# Altium schematic sheet 03_ATK-DLMP257_Power_USB.SchDoc
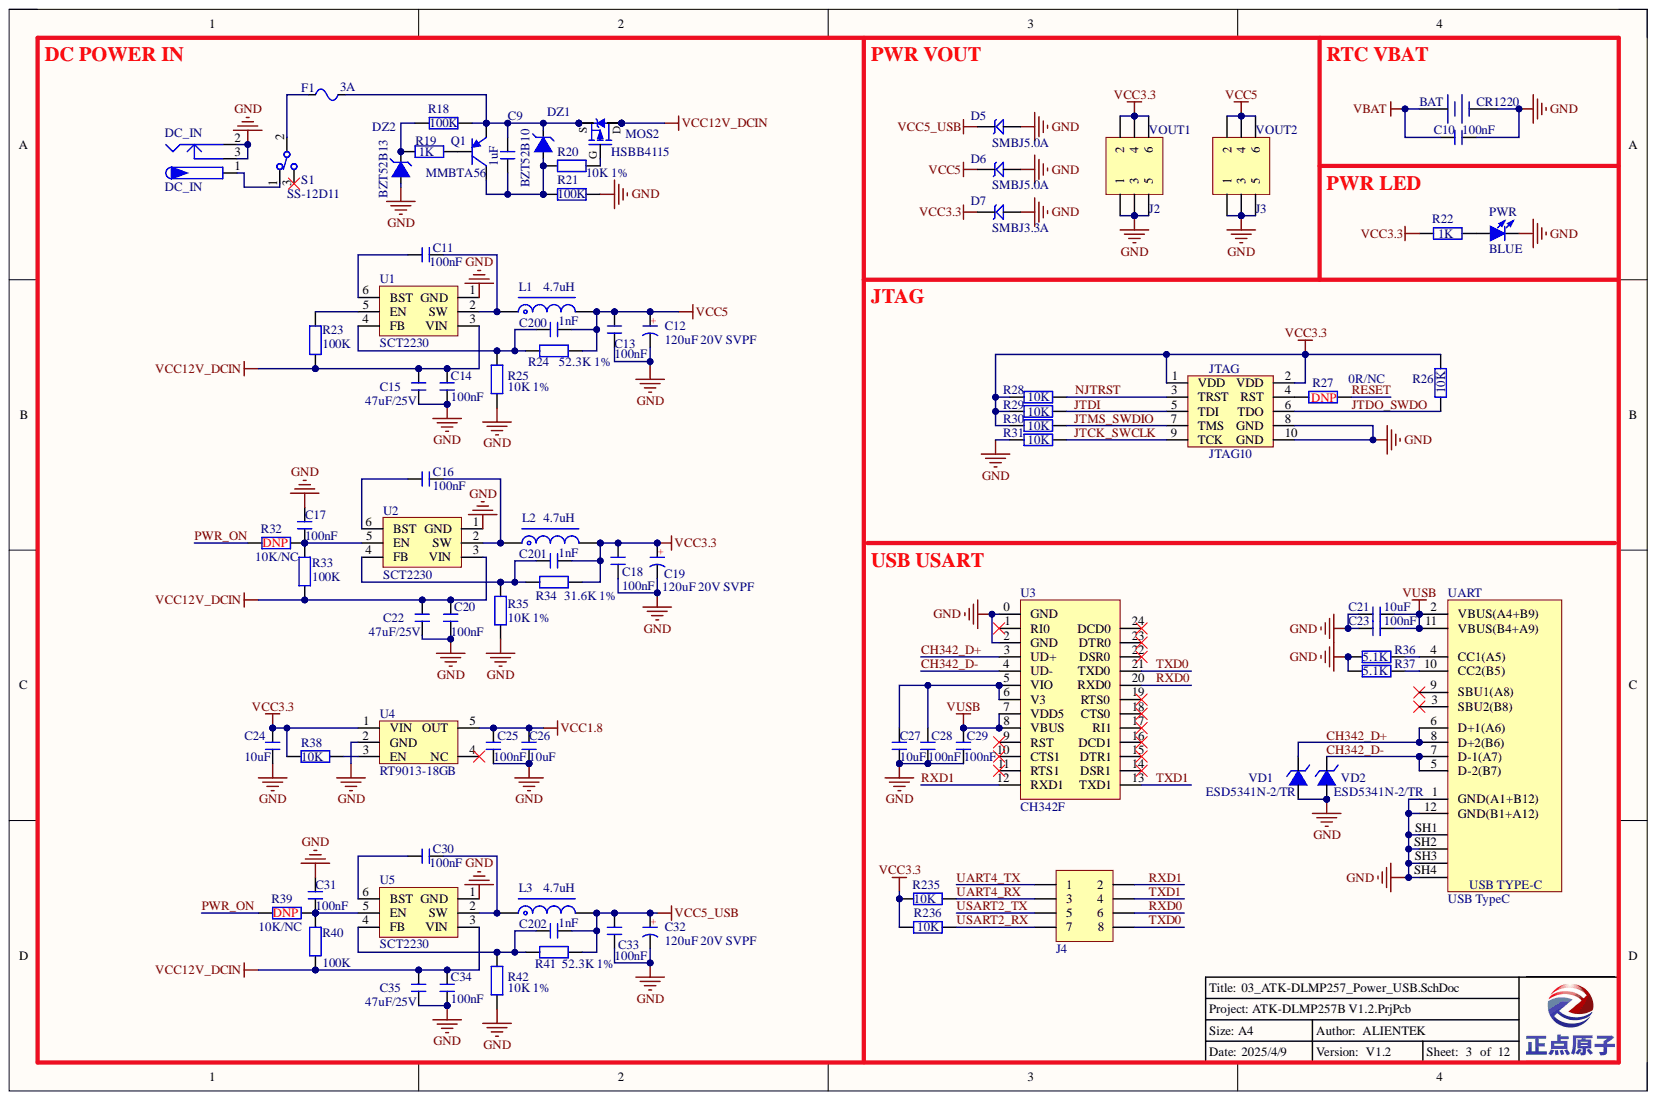
[diagram p0: 03_ATK-DLMP257_Power_USB.SchDoc]

## Parts
BAT  CR1220  [CR1220_A]
C9  1uF  [C 0603]
C10  100nF  [C 0603]
C11  100nF  [C 0603]
C12  120uF 20V SVPF  [CM D(6.3*7.7)]
C13  100nF  [C 0603]
C14  100nF  [C 0603]
C15  47uF/25V  [C 1206]
C16  100nF  [C 0603]
C17  100nF  [C 0603]
C18  100nF  [C 0603]
C19  120uF 20V SVPF  [CM D(6.3*7.7)]
C20  100nF  [C 0603]
C21  10uF  [C 0603]
C22  47uF/25V  [C 1206]
C23  100nF  [C 0603]
C24  10uF  [C 0603]
C25  100nF  [C 0603]
C26  10uF  [C 0603]
C27  10uF  [C 0603]
C28  100nF  [C 0603]
C29  100nF  [C 0603]
C30  100nF  [C 0603]
C31  100nF  [C 0603]
C32  120uF 20V SVPF  [CM D(6.3*7.7)]
C33  100nF  [C 0603]
C34  100nF  [C 0603]
C35  47uF/25V  [C 1206]
C200  1nF  [C 0603]
C201  1nF  [C 0603]
C202  1nF  [C 0603]
D5  SMBJ5.0A  [SMBJ]
D6  SMBJ5.0A  [SMBJ]
D7  SMBJ3.3A  [SMBJ]
DC_IN  DC_IN  [DC10B]
DZ1  BZT52B10  [SOD-123]
DZ2  BZT52B13  [SOD-123]
F1  3A  [FU 1812]
J2  VOUT1  [HDR-3X2]
J3  VOUT2  [HDR-3X2]
J4  Header 4X2  [Header 2.54 2X4P]  (value not drawn)
JTAG  JTAG10  [JTAG-10 2.0]
L1  4.7uH  [L-SMD-0420]
L2  4.7uH  [L-SMD-0420]
L3  4.7uH  [L-SMD-0420]
MOS2  HSBB4115  [DFN8_ 3x3]
PWR  BLUE  [LED 0805B]
Q1  MMBTA56  [SOT23-3N]
R18  100K  [R 0603]
R19  1K  [R 0603]
R20  10K 1%  [R 0603]
R21  100K  [R 0603]
R22  1K  [R 0603]
R23  100K  [R 0603]
R24  52.3K 1%  [R 0603]
R25  10K 1%  [R 0603]
R26  10K  [R 0603]
R27  0R/NC  [R 0603]
R28  10K  [R 0603]
R29  10K  [R 0603]
R30  10K  [R 0603]
R31  10K  [R 0603]
R32  10K/NC  [R 0603]
R33  100K  [R 0603]
R34  31.6K 1%  [R 0603]
R35  10K 1%  [R 0603]
R36  5.1K  [R 0603]
R37  5.1K  [R 0603]
R38  10K  [R 0603]
R39  10K/NC  [R 0603]
R40  100K  [R 0603]
R41  52.3K 1%  [R 0603]
R42  10K 1%  [R 0603]
R235  10K  [R 0603]
R236  10K  [R 0603]
S1  SS-12D11  [SS-12D06]
U1  SCT2230  [SOT23-6N]
U2  SCT2230  [SOT23-6N]
U3  CH342F  [QFN24 4x4_N]
U4  RT9013-18GB  [SOT23-5N]
U5  SCT2230  [SOT23-6N]
UART  USB TypeC  [USB2.0-TYPE-C-16P]
VD1  ESD5341N-2/TR  [ESD0402]
VD2  ESD5341N-2/TR  [ESD0402]

## Nets
GND: BAT.2 C10.2 C12.2 C13.2 C14.1 C15.1 C17.2 C18.2 C19.2 C20.1 C21.2 C22.1 C23.2 C24.1 C25.1 C26.1 C27.1 C28.1 C29.1 C31.2 C32.2 C33.2 C34.1 C35.1 D5.1 D6.1 D7.1 DC_IN.2 DC_IN.3 DZ2.1 J2.1 J2.3 J2.5 J3.1 J3.3 J3.5 JTAG.8(GND) JTAG.10(GND) PWR.2 R21.2 R25.2 R31.1 R35.2 R36.1 R37.1 R42.2 U1.1(GND) U2.1(GND) U3.0(GND) U3.2(GND) U4.2(GND) U5.1(GND) UART.1(GND(A1+B12)) UART.12(GND(B1+A12)) UART.SH1(Shield1) UART.SH2(Shield2) UART.SH3(Shield3) UART.SH4(Shield4) VD1.2 VD2.2
VBAT: BAT.1 C10.1
VCC1.8: C25.2 C26.2 U4.5(OUT)
VCC3.3: C18.1 C19.1 C24.2 C201.1 D7.2 J2.2 J2.4 J2.6 JTAG.1(VDD) JTAG.2(VDD) L2.2 R22.2 R26.1 R28.2 R29.2 R30.2 R34.2 R38.2 R235.2 R236.1 U4.1(VIN)
VCC5: C12.1 C13.1 C200.1 D6.2 J3.2 J3.4 J3.6 L1.2 R24.2
VCC5_USB: C32.1 C33.1 C202.1 D5.2 L3.2 R41.2
VCC12V_DCIN: C14.2 C15.2 C20.2 C22.2 C34.2 C35.2 MOS2.5 MOS2.6 MOS2.7 MOS2.8 R23.2 R33.1 R40.2 U1.3(VIN) U2.3(VIN) U5.3(VIN)
VUSB: C21.1 C23.1 C29.2 U3.7(VDD5) U3.8(VBUS) UART.2(VBUS(A4+B9)) UART.11(VBUS(B4+A9))
CH342_D+: U3.3(UD+) UART.6(D+1(A6)) UART.8(D+2(B6)) VD1.1
CH342_D-: U3.4(UD-) UART.5(D-2(B7)) UART.7(D-1(A7)) VD2.1
JTCK_SWCLK: JTAG.9(TCK) R31.2
JTDI: JTAG.5(TDI) R29.1
JTDO_SWDO: JTAG.6(TDO) R26.2
JTMS_SWDIO: JTAG.7(TMS) R30.1
NJTRST: JTAG.3(TRST) R28.1
PWR_ON: R32.2 R39.2
RESET: R27.2
RXD0: J4.6 U3.20(RXD0)
RXD1: J4.2 U3.12(RXD1)
TXD0: J4.8 U3.21(TXD0)
TXD1: J4.4 U3.13(TXD1)
UART4_RX: J4.3 R235.1
UART4_TX: J4.1
USART2_RX: J4.7 R236.2
USART2_TX: J4.5
NetC9_1: C9.1 DZ1.2 F1.1 MOS2.1 MOS2.2 MOS2.3 Q1.2 R18.1
NetC9_2: C9.2 DZ1.1 Q1.3 R20.1 R21.1
NetC11_1: C11.1 U1.6(BST)
NetC11_2: C11.2 L1.1 U1.2(SW)
NetC16_1: C16.1 U2.6(BST)
NetC16_2: C16.2 L2.1 U2.2(SW)
NetC17_1: C17.1 R32.1 R33.2 U2.5(EN)
NetC27_2: C27.2 C28.2 U3.5(VIO) U3.6(V3)
NetC30_1: C30.1 U5.6(BST)
NetC30_2: C30.2 L3.1 U5.2(SW)
NetC31_1: C31.1 R39.1 R40.1 U5.5(EN)
NetC200_2: C200.2 R24.1 R25.1 U1.4(FB)
NetC201_2: C201.2 R34.1 R35.1 U2.4(FB)
NetC202_2: C202.2 R41.1 R42.1 U5.4(FB)
NetDC_IN_1: DC_IN.1 S1.1
NetDZ2_2: DZ2.2 R18.2 R19.1
NetF1_2: F1.2 S1.2
NetJTAG_4: JTAG.4(RST) R27.1
NetMOS2_4: MOS2.4 R20.2
NetPWR_1: PWR.1 R22.1
NetQ1_1: Q1.1 R19.2
NetR23_1: R23.1 U1.5(EN)
NetR36_2: R36.2 UART.4(CC1(A5))
NetR37_2: R37.2 UART.10(CC2(B5))
NetR38_1: R38.1 U4.3(EN)

Not connected: S1 3; U3 1(RI0) 9(RST) 10(CTS1) 11(RTS1) 14(DSR1) 15(DTR1) 16(DCD1) 17(RI1) 18(CTS0) 19(RTS0) 22(DSR0) 23(DTR0) 24(DCD0); U4 4(NC); UART 3(SBU2(B8)) 9(SBU1(A8))

## Library data of the parts
BAT = CR-3V: 微型电池; 版权归属: 正点原子; BOM名称: 微型电池; Component Kind: Standard; Library Name: 未分类器件.SchLib; 学习资源: www.openedv.com
C9 = C: 50V 10% 无极性贴片电容; BOM名称: 电容; 版权归属: 正点原子; Component Kind: Standard; Library Name: 电阻-电容-电感.SchLib; 学习资源: www.openedv.com
C10 = C: 无极性贴片电容; 版权归属: 正点原子; BOM名称: 无极性贴片电容; Component Kind: Standard; Library Name: 电阻-电容-电感.SchLib; 学习资源: www.openedv.com
C11 C13-C18 C20-C31 C33-C35 C200-C202 = C: 无极性贴片电容; 版权归属: 源创客; BOM名称: 无极性贴片电容; Component Kind: Standard; 学习资源: www.ooocke.com
  C11 C13-C18 C20 C22 C24-C31 C33-C35 C200-C202: Library Name: 电阻-电容-电感.SchLib
  C21 C23: Library Name: Lycreturn.IntLib
C12 C19 C32 = C-CM: 贴片电解电容; 版权归属: 源创客; BOM名称: 贴片电解电容; Component Kind: Standard; Library Name: 电阻-电容-电感.SchLib; 学习资源: www.ooocke.com
D5-D7 = SMBJ: TVS; Component Kind: Standard; Library Name: ALIENTEK_COMPONENT.IntLib
DC_IN = P-DC: 低压电源接口; BOM名称: DC电源接口; 版权归属: 源创客; Component Kind: Standard; Library Name: 接口类.SchLib; 学习资源: www.ooocke.com
DZ1 DZ2 = BZT52BxVx: CJ x.xV / 0.5W稳压管  2%; BOM名称: 二极管(稳压); 版权归属: 正点原子; Component Kind: Standard; Library Name: 二极管-整流桥.SchLib; 学习资源: www.openedv.com
F1 = FU: 贴片保险丝; 版权归属: 源创客; BOM名称: 贴片保险丝; Component Kind: Standard; Library Name: 保险丝-晶振.SchLib; 学习资源: www.ooocke.com
J2 J3 = Header 3X2: 3*2P接插件; 版权归属: 源创客; BOM名称: 3*2P接插件; Component Kind: Standard; 学习资源: www.ooocke.com; Library Name: Lycreturn.IntLib
J4 = Header 4X2: 4*2P接插件; Parameter: 接插件; 版权归属: 正点原子; BOM名称: 4*2P接插件; Component Kind: Standard; Library Name: 正点原子封装库V1.0.IntLib; 学习资源: www.openedv.com
JTAG = JTAG-10 2.0: Component Kind: Standard; Library Name: ZZK_COMPONENT.IntLib
L1-L3 = L-CDRH: 功率屏蔽电感; 版权归属: 源创客; BOM名称: 功率屏蔽电感; Component Kind: Standard; Library Name: 电阻-电容-电感.SchLib; 学习资源: www.ooocke.com
MOS2 = HSBB6115: PMOS -60V -8A@-4.5V 33mΩ; BOM名称: MOS管; 版权归属: 正点原子; Component Kind: Standard; Library Name: 场效应管-可控硅.SchLib; 学习资源: www.openedv.com
PWR = LED-SMD: 贴片LED; 版权归属: 源创客; BOM名称: 贴片LED; Component Kind: Standard; Library Name: LED.SchLib; 学习资源: www.ooocke.com
Q1 = 9015-SMD: 低噪放大-PNP型; BOM名称: 三极管; 属性: 50V 0.1A 0.4W 150MHZ; 版权归属: 正点原子; Component Kind: Standard; Library Name: 三极管.SchLib; 学习资源: www.ooocke.com
R18-R21 = R: 贴片电阻; BOM名称: 电阻; 版权归属: 正点原子; Component Kind: Standard; Library Name: 电阻-电容-电感.SchLib; 学习资源: www.openedv.com
R22-R25 R32-R42 = R: 贴片电阻; 版权归属: 源创客; BOM名称: 贴片电阻; Component Kind: Standard; 学习资源: www.ooocke.com
  R22-R25 R32-R35 R38-R42: Library Name: 电阻-电容-电感.SchLib
  R36 R37: Library Name: Lycreturn.IntLib
R26-R31 R235 R236 = R: 贴片电阻; 版权归属: 正点原子; BOM名称: 贴片电阻; Component Kind: Standard; 学习资源: www.openedv.com; Library Name: Lycreturn.IntLib
S1 = SSW-1P: 1路波动开关; BOM名称: 波动开关; 版权归属: 源创客; Component Kind: Standard; Library Name: 按键-开关.SchLib; 学习资源: www.ooocke.com
U1 U2 U5 = DC JW5060T_6: DC降压芯片; Component Kind: Standard; Library Name: LDO和DCDC.SchLib
U3 = CH342F: USB转2路串口,24脚; Component Kind: Standard; Library Name: ATK-DLIMX93_Library_V1.0.IntLib
U4 = RT9013: LDO 5.5V 500mA; BOM名称: 稳压芯片; Parameter: 芯片; 版权归属: 正点原子; Component Kind: Standard; Library Name: 正点原子封装库V1.0.IntLib; 学习资源: www.openedv.com
UART = USB Type-C: 12P TypeC 母座; Parameter: 接口器件; 版权归属: 正点原子; Component Kind: Standard; 学习资源: www.openedv.com; Library Name: Lycreturn.IntLib
VD1 VD2 = ESD5341N: ESD二极管-单向; Component Kind: Standard; Library Name: ATK-DLMP257_V1.0.SCHLIB
